# Altium schematic sheet Debug Connector.SchDoc
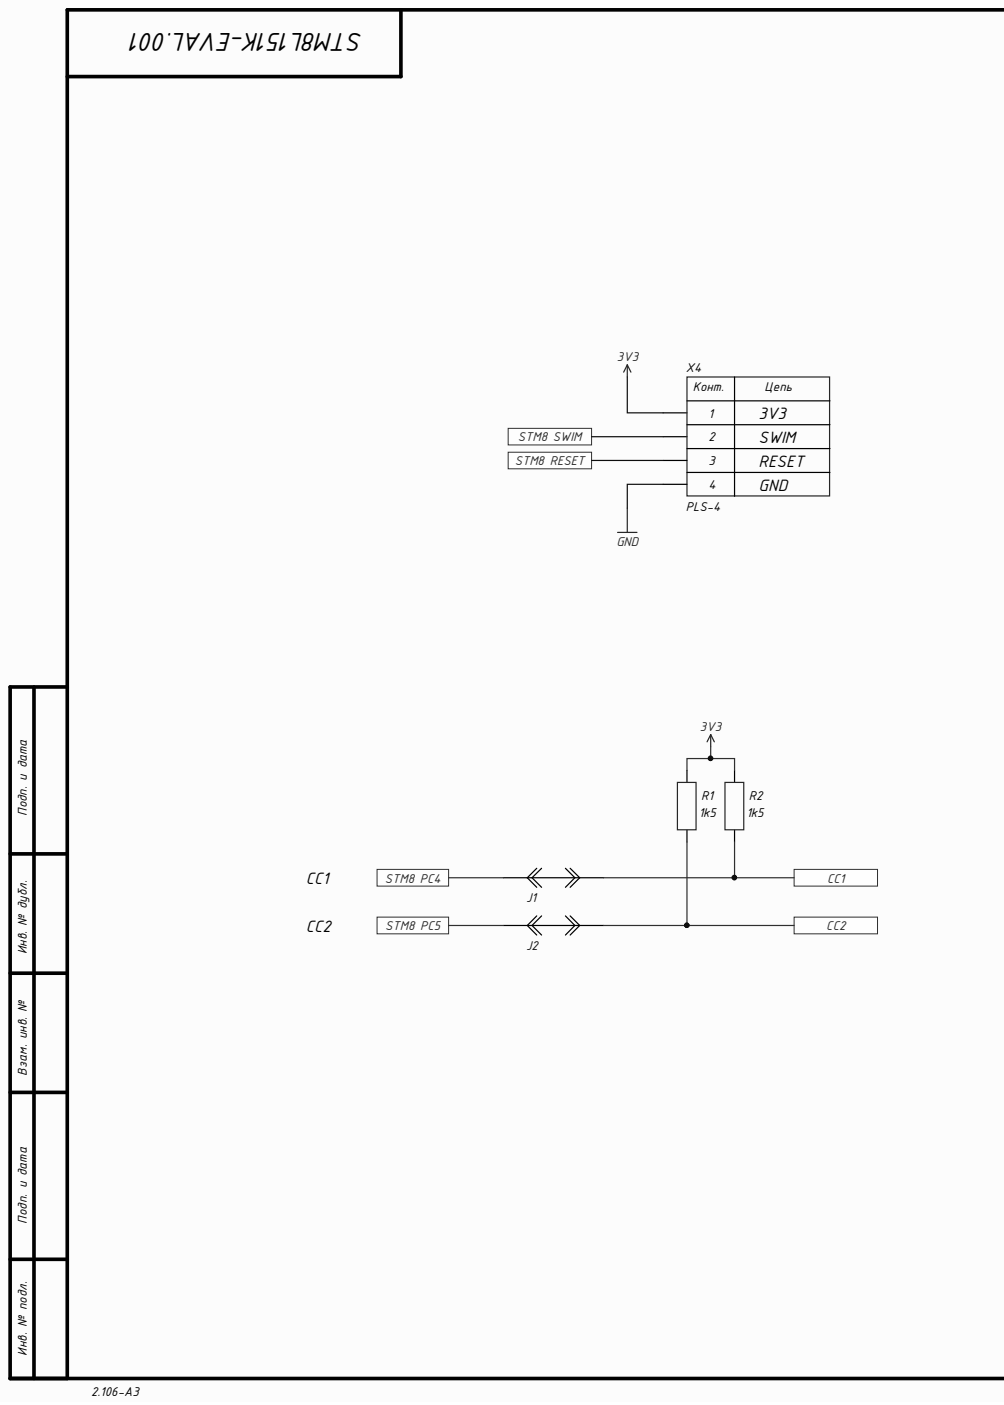
[diagram p0: Debug Connector.SchDoc, left]
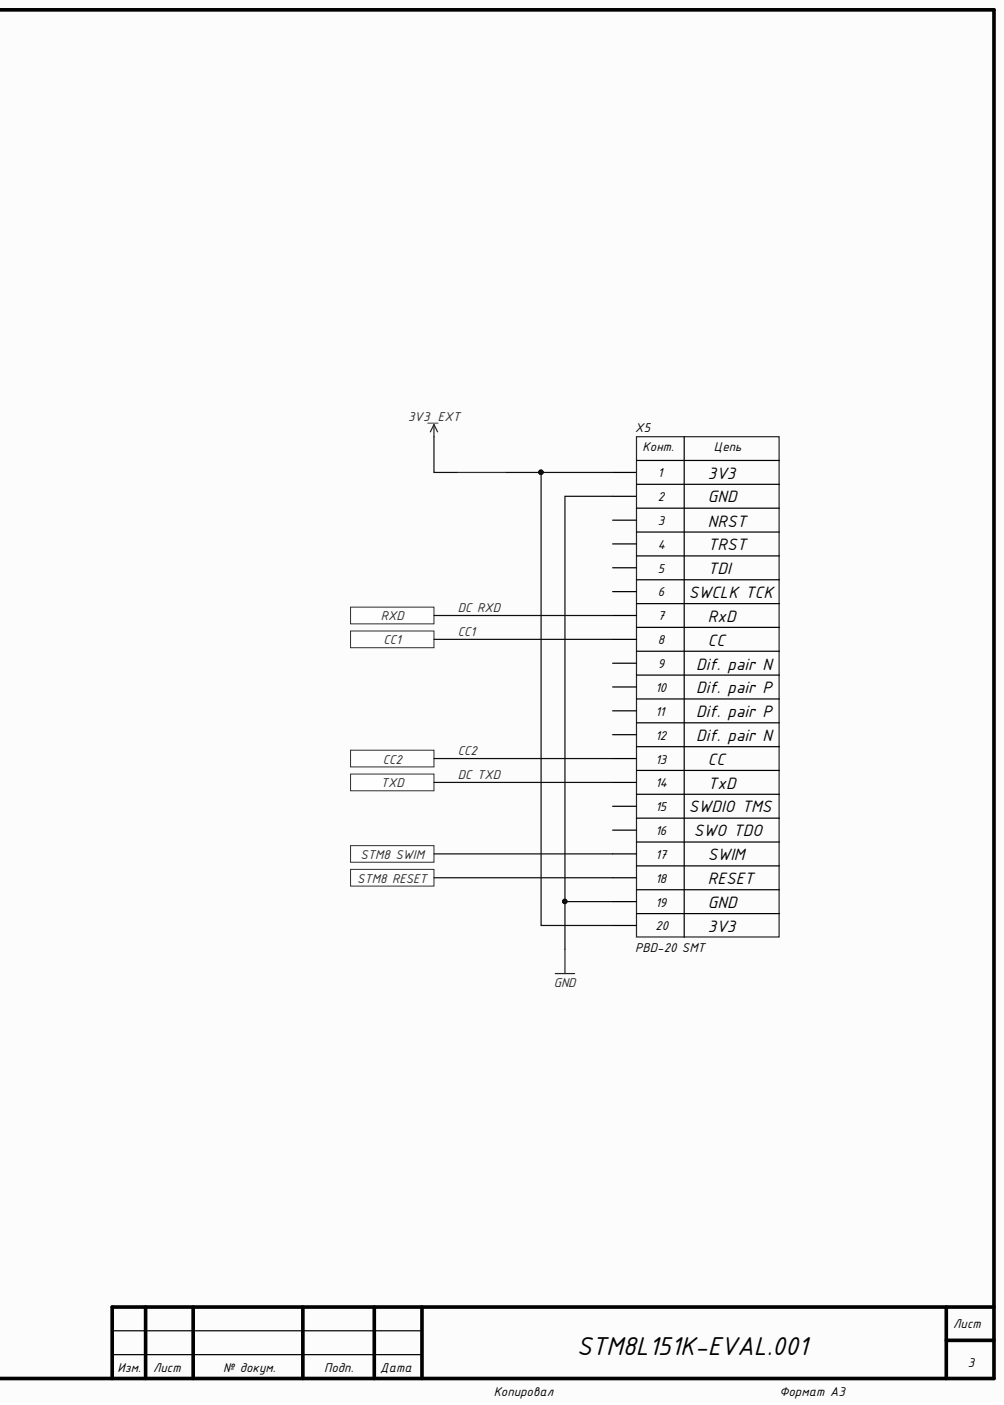
[diagram p1: Debug Connector.SchDoc, right]

## Parts
J1  Jumper  [CON:JUMPER SMT 2-way]  (value not drawn)
J2  Jumper  [CON:JUMPER SMT 2-way]  (value not drawn)
R1  1k5  [CH0603R]
R2  1k5  [CH0603R]
X4  PLS-4  [CON: PLS-4]
X5  PBD-20 SMT  [CON: PBD-20  SMT]

## Ports
CC1, CC2, RXD, STM8 PC4, STM8 PC5, STM8 RESET, STM8 SWIM, TXD

## Nets
3V3: R1.2 R2.2 X4.1
3V3_EXT: X5.1 X5.20
GND: X4.4 X5.2 X5.19
CC1: J1.2 R2.1 X5.8 ; port CC1
CC2: J2.2 R1.1 X5.13 ; port CC2
DC RXD: X5.7 ; port RXD
DC TXD: X5.14 ; port TXD
STM8 PC4: J1.1 ; port STM8 PC4
STM8 PC5: J2.1 ; port STM8 PC5
STM8 RESET: X4.3 X5.18 ; port STM8 RESET
STM8 SWIM: X4.2 X5.17 ; port STM8 SWIM

Not connected: X5 3 4 5 6 9 10 11 12 15 16

## Library data of the parts
J1 J2 = Jumper 2-way: 2-way jumper; Component Kind: Standard; Library Name: Connectors.IntLib
R1 R2 = Resistor: Component Kind: Standard; Library Name: Discrete.IntLib; Value: 1k5
X4 = 4-Connector: Component Kind: Standard; Library Name: Connectors.IntLib; Model: PLS-4
X5 = 20-Connector: Component Kind: Standard; Library Name: Connectors.IntLib; Model: PBD-20 SMT
